# Altium schematic sheet Microcontroller.SchDoc(STM32_Micro)
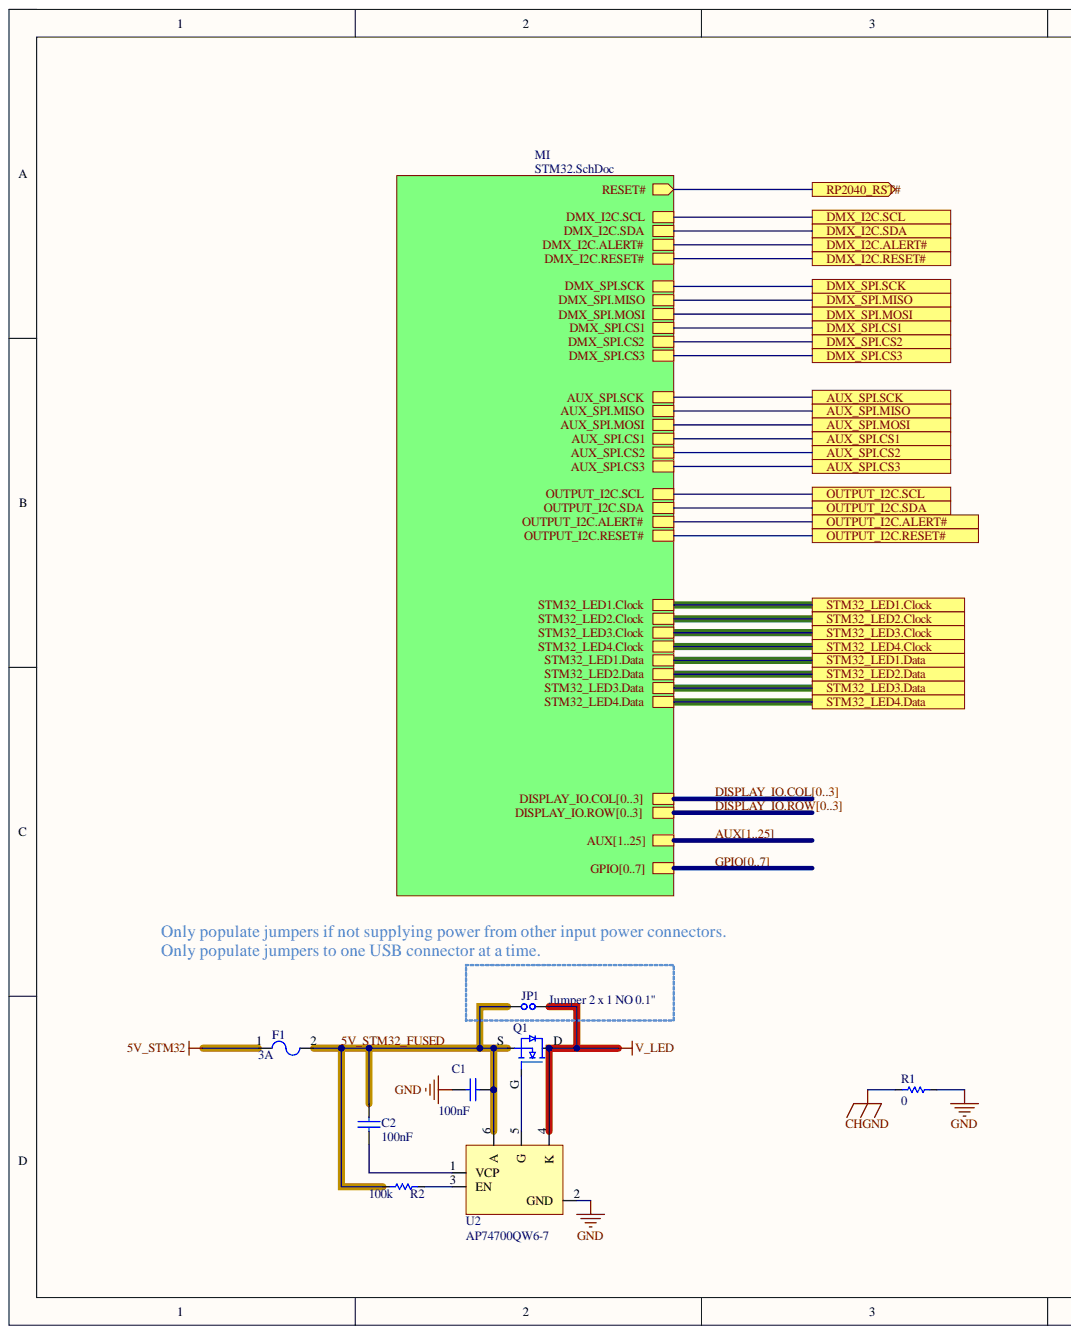
[diagram p0: Microcontroller.SchDoc, left]
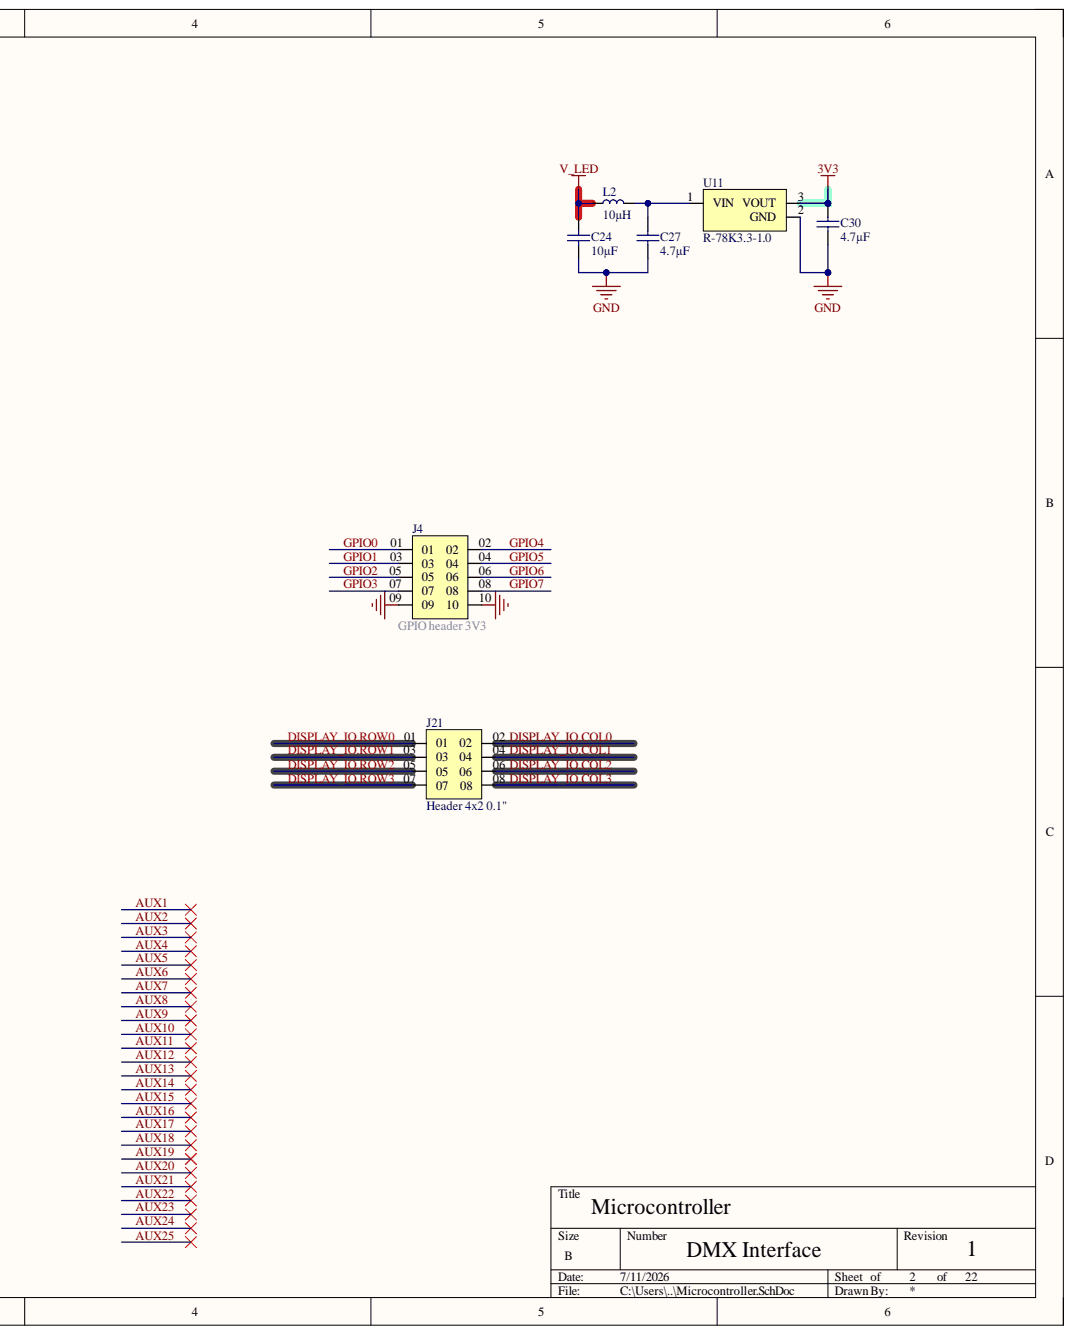
[diagram p1: Microcontroller.SchDoc, right]

## Parts
C1  value 100nF  [CAPC1608X10N_0603_MED]  (hidden comment: CC0603KRX7R0BB104)
C2  value 100nF  [CAPC1608X10N_0603_MED]  (hidden comment: CC0603KRX7R0BB104)
C24  value 10µF  [CAPC2012X14N_0805_MED]  (hidden comment: GRM21BR61H106KE43L)
C27  value 4.7µF  [CAPC2012X14N_0805_MED]  (hidden comment: GRM21BR61H475KE51L)
C30  value 4.7µF  [CAPC2012X14N_0805_MED]  (hidden comment: GRM21BR61H475KE51L)
F1  Current Rating: 3A  [FUSE4532X1.55N_1812MED]  (hidden comment: 0ZCG0300FF2B)
J4  Header 5x2 0.1"  [HEADER 5x2 0.1 Through hole]  (value not drawn)
J21  Header 4x2 0.1"  [Header 4x2 0.1"]
JP1  Jumper 2 x 1 NO 0.1"  [FP-M20-9990245-MFG]
L2  value 10µH  [FP-SRN5040-MFG]  (hidden comment: SRN5040-100M)
Q1  BUK9880-55A/CUX  [SOT230P700X180-4N]  (value not drawn)
R1  value 0  [RESC1608X06N_0603_MED]  (hidden comment: RC0603FR-070RL)
R2  value 100k  [RESC1608X06N_0603_MED]  (hidden comment: RC0603DR-07100KL)
U2  AP74700QW6-7  [SOT95P285X130-6N]
U11  R-78K3.3-1.0  [R-78K3.3-1.0-Footprint-1]

## Ports
AUX_SPI.CS1, AUX_SPI.CS2, AUX_SPI.CS3, AUX_SPI.MISO, AUX_SPI.MOSI, AUX_SPI.SCK, DMX_I2C.ALERT#, DMX_I2C.RESET#, DMX_I2C.SCL, DMX_I2C.SDA, DMX_SPI.CS1, DMX_SPI.CS2, DMX_SPI.CS3, DMX_SPI.MISO, DMX_SPI.MOSI, DMX_SPI.SCK, OUTPUT_I2C.ALERT#, OUTPUT_I2C.RESET#, OUTPUT_I2C.SCL, OUTPUT_I2C.SDA, RESET#, STM32_LED1.Clock, STM32_LED1.Data, STM32_LED2.Clock, STM32_LED2.Data, STM32_LED3.Clock, STM32_LED3.Data, STM32_LED4.Clock, STM32_LED4.Data

## Nets
3V3: C30.2 U11.3
5V_STM32: F1.1
CHGND: R1.1
GND: C1.2 C24.1 C27.1 C30.1 J4.09 J4.10 R1.2 U2.2(GND) U11.2
V_LED: C24.2 JP1.2 L2.1 Q1.D U2.4(K)
5V_STM32_FUSED: C1.1 C2.2 F1.2 JP1.1 Q1.S R2.1 U2.6(A)
DISPLAY_IO.COL0: J21.02
DISPLAY_IO.COL1: J21.04
DISPLAY_IO.COL2: J21.06
DISPLAY_IO.COL3: J21.08
DISPLAY_IO.ROW0: J21.01
DISPLAY_IO.ROW1: J21.03
DISPLAY_IO.ROW2: J21.05
DISPLAY_IO.ROW3: J21.07
GPIO0: J4.01
GPIO1: J4.03
GPIO2: J4.05
GPIO3: J4.07
GPIO4: J4.02
GPIO5: J4.04
GPIO6: J4.06
GPIO7: J4.08
NetC2_1: C2.1 U2.1(VCP)
NetC27_2: C27.2 L2.2 U11.1
NetQ1_G: Q1.G U2.5(G)
NetR2_2: R2.2 U2.3(EN)
AUX1:
AUX2:
AUX3:
AUX4:
AUX5:
AUX6:
AUX7:
AUX8:
AUX9:
AUX10:
AUX11:
AUX12:
AUX13:
AUX14:
AUX15:
AUX16:
AUX17:
AUX18:
AUX19:
AUX20:
AUX21:
AUX22:
AUX23:
AUX24:
AUX25:
AUX_SPI.CS1: ; port AUX_SPI.CS1
AUX_SPI.CS2: ; port AUX_SPI.CS2
AUX_SPI.CS3: ; port AUX_SPI.CS3
AUX_SPI.MISO: ; port AUX_SPI.MISO
AUX_SPI.MOSI: ; port AUX_SPI.MOSI
AUX_SPI.SCK: ; port AUX_SPI.SCK
DMX_I2C.ALERT#: ; port DMX_I2C.ALERT#
DMX_I2C.RESET#: ; port DMX_I2C.RESET#
DMX_I2C.SCL: ; port DMX_I2C.SCL
DMX_I2C.SDA: ; port DMX_I2C.SDA
DMX_SPI.CS1: ; port DMX_SPI.CS1
DMX_SPI.CS2: ; port DMX_SPI.CS2
DMX_SPI.CS3: ; port DMX_SPI.CS3
DMX_SPI.MISO: ; port DMX_SPI.MISO
DMX_SPI.MOSI: ; port DMX_SPI.MOSI
DMX_SPI.SCK: ; port DMX_SPI.SCK
OUTPUT_I2C.ALERT#: ; port OUTPUT_I2C.ALERT#
OUTPUT_I2C.RESET#: ; port OUTPUT_I2C.RESET#
OUTPUT_I2C.SCL: ; port OUTPUT_I2C.SCL
OUTPUT_I2C.SDA: ; port OUTPUT_I2C.SDA
RESET#: ; port RESET#
STM32_LED1.Clock: ; port STM32_LED1.Clock
STM32_LED1.Data: ; port STM32_LED1.Data
STM32_LED2.Clock: ; port STM32_LED2.Clock
STM32_LED2.Data: ; port STM32_LED2.Data
STM32_LED3.Clock: ; port STM32_LED3.Clock
STM32_LED3.Data: ; port STM32_LED3.Data
STM32_LED4.Clock: ; port STM32_LED4.Clock
STM32_LED4.Data: ; port STM32_LED4.Data

## Library data of the parts
C1 C2 = CMP-001-00102-4: Ceramic Capacitor, Multilayer, Ceramic, 100V, 10% +Tol, 10% -Tol, X7R, 15% TC, 0.1uF, Surface Mount, 0603; Capacitor Type: Ceramic; Case/Package: 0603; Component Kind: Standard; JLCPN: C113803; Max Operating Temperature: 125°C; Min Operating Temperature: -55°C; Mounting Technology: Surface Mount; Pins: 2; RoHS Compliant: Yes; Voltage Rating: 100V; Library Name: Equus Computer Systems
C24 = CMP-001-00169-2: Ceramic Capacitor, Multilayer, Ceramic, 10uF, 50V, 10%, X6S, 0805; Capacitor Type: Ceramic; Case/Package: 0805; Component Kind: Standard; JLCPN: C440198; Max Operating Temperature: 85°C; Min Operating Temperature: -55°C; Mounting Technology: Surface Mount; Pins: 2; RoHS Compliant: Yes; Tolerance: 10%; Voltage Rating: 50V; Library Name: Equus Computer Systems
C27 C30 = CMP-001-00165-2: Ceramic Capacitor, Multilayer, Ceramic, 50V, 10% +Tol, 10% -Tol, X5R, 15% TC, 4.7uF, Surface Mount, 0805; Capacitor Type: Ceramic; Case/Package: 0805; Component Kind: Standard; JLCPN: C162420; Max Operating Temperature: 85°C; Min Operating Temperature: -55°C; Mounting Technology: Surface Mount; Pins: 2; RoHS Compliant: Yes; Tolerance: 10%; Voltage Rating: 50V; Library Name: Equus Computer Systems
F1 = CMP-005-00018-1: PTC Resettable Fuse, 6V, 3A Surface Mount; Case/Package: 1812; Component Kind: Standard; Max Operating Temperature: 85°C; Min Operating Temperature: -40°C; Mounting Technology: SMT; Pins: 2; RoHS Compliant: Yes; Library Name: Equus Computer Systems
J4 = CMP-002-00228-3: Board Connector Tall, 10 Contact(s), 2 Row(s), Male, Straight, 0.1 inch Pitch; Max Current Rating: 3A; Component Kind: Standard; Connector Type: Header; Gender: Male; Mounting Technology: Board; Pins: 10; RoHS Compliant: Yes; Library Name: Equus Computer Systems
J21 = CMP-002-00227-2: Board Connector, 8 Contact(s), 2 Row(s), Female, 0.1 inch Pitch; Gender: Male; Max Current Rating: 3A; Component Kind: Standard; Connector Type: BOARD CONNECTOR; Max Operating Temperature: 105°C; Min Operating Temperature: -40°C; Mounting Technology: Board; Pins: 8; RoHS Compliant: Yes; Library Name: Equus Computer Systems
JP1 = CMP-002-00086-5: Connector Type: BOARD CONNECTOR; Component Kind: Standard; Description: Jumper 2 x 1 NO 0.1"; Max Operating Temperature: 105°C; Min Operating Temperature: -40°C; Mounting Technology: Through Hole; Pins: 2; RoHS Compliant: Yes; Library Name: Equus Computer Systems
L2 = CMP-006-00030-2: General Purpose Inductor, 10uH, 20%, 1 Element, Ferrite-Core, SMD, 2020; Max Current Rating: 2.1A; Self Resonant Frequency: 17MHz; Component Kind: Standard; JLCPN: C1329529; Max Operating Temperature: 125°C; Min Operating Temperature: -40°C; Mounting Technology: SMT; Pins: 2; RoHS Compliant: Yes; Tolerance: 20%; Library Name: Equus Computer Systems
Q1 = CMP-013-00051-4: BUK9880 Series 55 V 7A 73 mOhm N-Channel TrenchMOS Logic Level FET - SC-73; Vgs (Max): 15V; Case/Package: SOT; Component Kind: Standard; Max Operating Temperature: 150°C; Min Operating Temperature: -55°C; Pins: 4; Vgs(th) (Max) @ Id: v; Library Name: Equus Computer Systems
R1 = CMP-009-00204-3: RC Series 0603 0.1 W 0 Ohm Jumper Surface Mount Thick Film Chip Resistor; Power: 100mW; Tolerance: 1%; Voltage Rating: 75V; Case/Package: 0603; Component Kind: Standard; JLCPN: C100044; Max Operating Temperature: 155°C; Min Operating Temperature: -55°C; Mounting Technology: Surface Mount; Pins: 2; RoHS Compliant: Yes; Library Name: Equus Computer Systems
R2 = CMP-009-00328-2: Fixed Resistor, Metal Glaze/thick Film, 0.1W, 100000ohm, 75V, 0.5% +/-Tol, 100ppm/Cel, Surface Mount, 0603; Power: 100mW; Voltage Rating: 50V; Case/Package: 0603; Component Kind: Standard; JLCPN: C163504; Max Operating Temperature: 155°C; Min Operating Temperature: -55°C; Mounting Technology: Surface Mount; Pins: 2; RoHS Compliant: Yes; Tolerance: 0.5%; Library Name: Equus Computer Systems
U2 = CMP-004-00052-1: ORController N-Channel Single Automotive AEC-Q100 6-Pin SOT-26 T/R; Component Kind: Standard; Max Operating Temperature: 125°C; Min Operating Temperature: -40°C; Library Name: Equus Computer Systems
U11 = CMP-019-00075-1: R-78K-1.0 Series Single Output 3.3 V 1 A Non Isolated DC/DC Converter - SIP-3; Max Supply Voltage: 36V; Min Supply Voltage: 4.75V; Output Current: 1A; Output Voltage: 3.3V; Component Kind: Standard; Pins: 3; Library Name: Equus Computer Systems
